# Altium schematic sheet nrf52840_v1.SchDoc
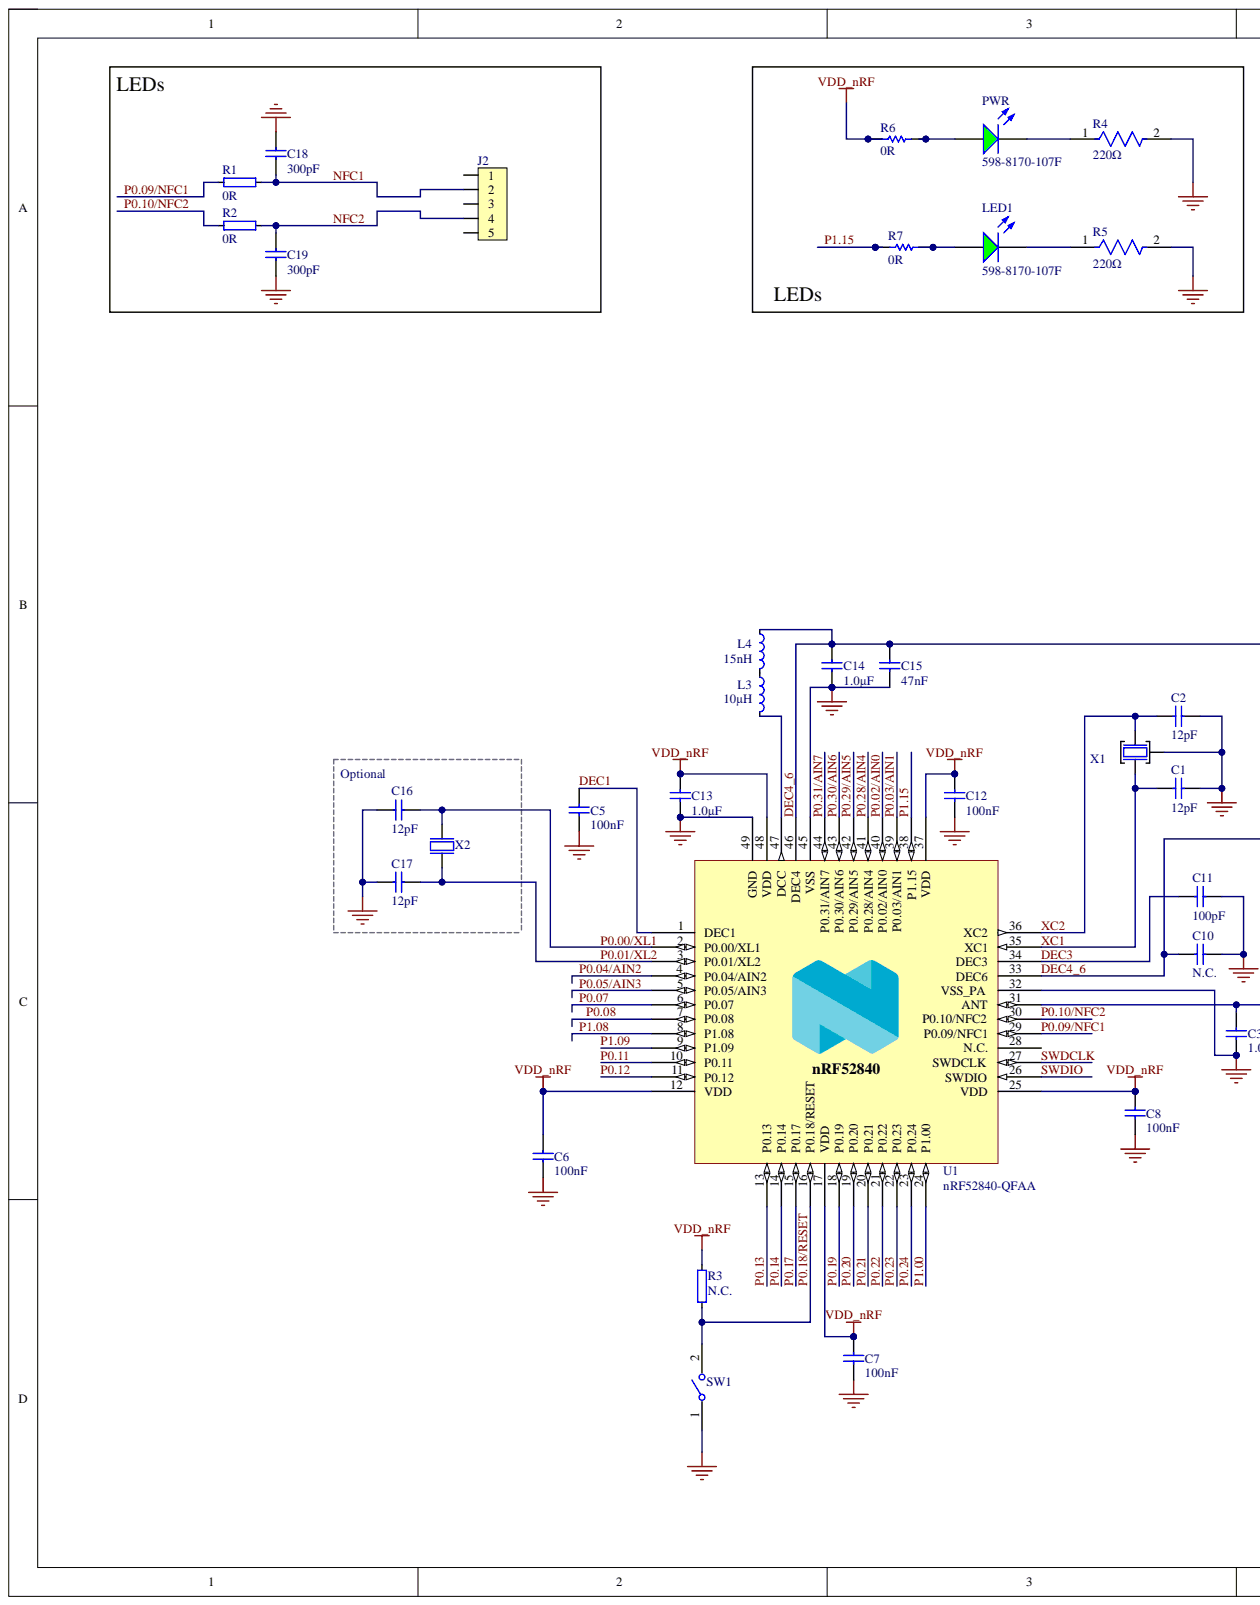
[diagram p0: nrf52840_v1.SchDoc, left]
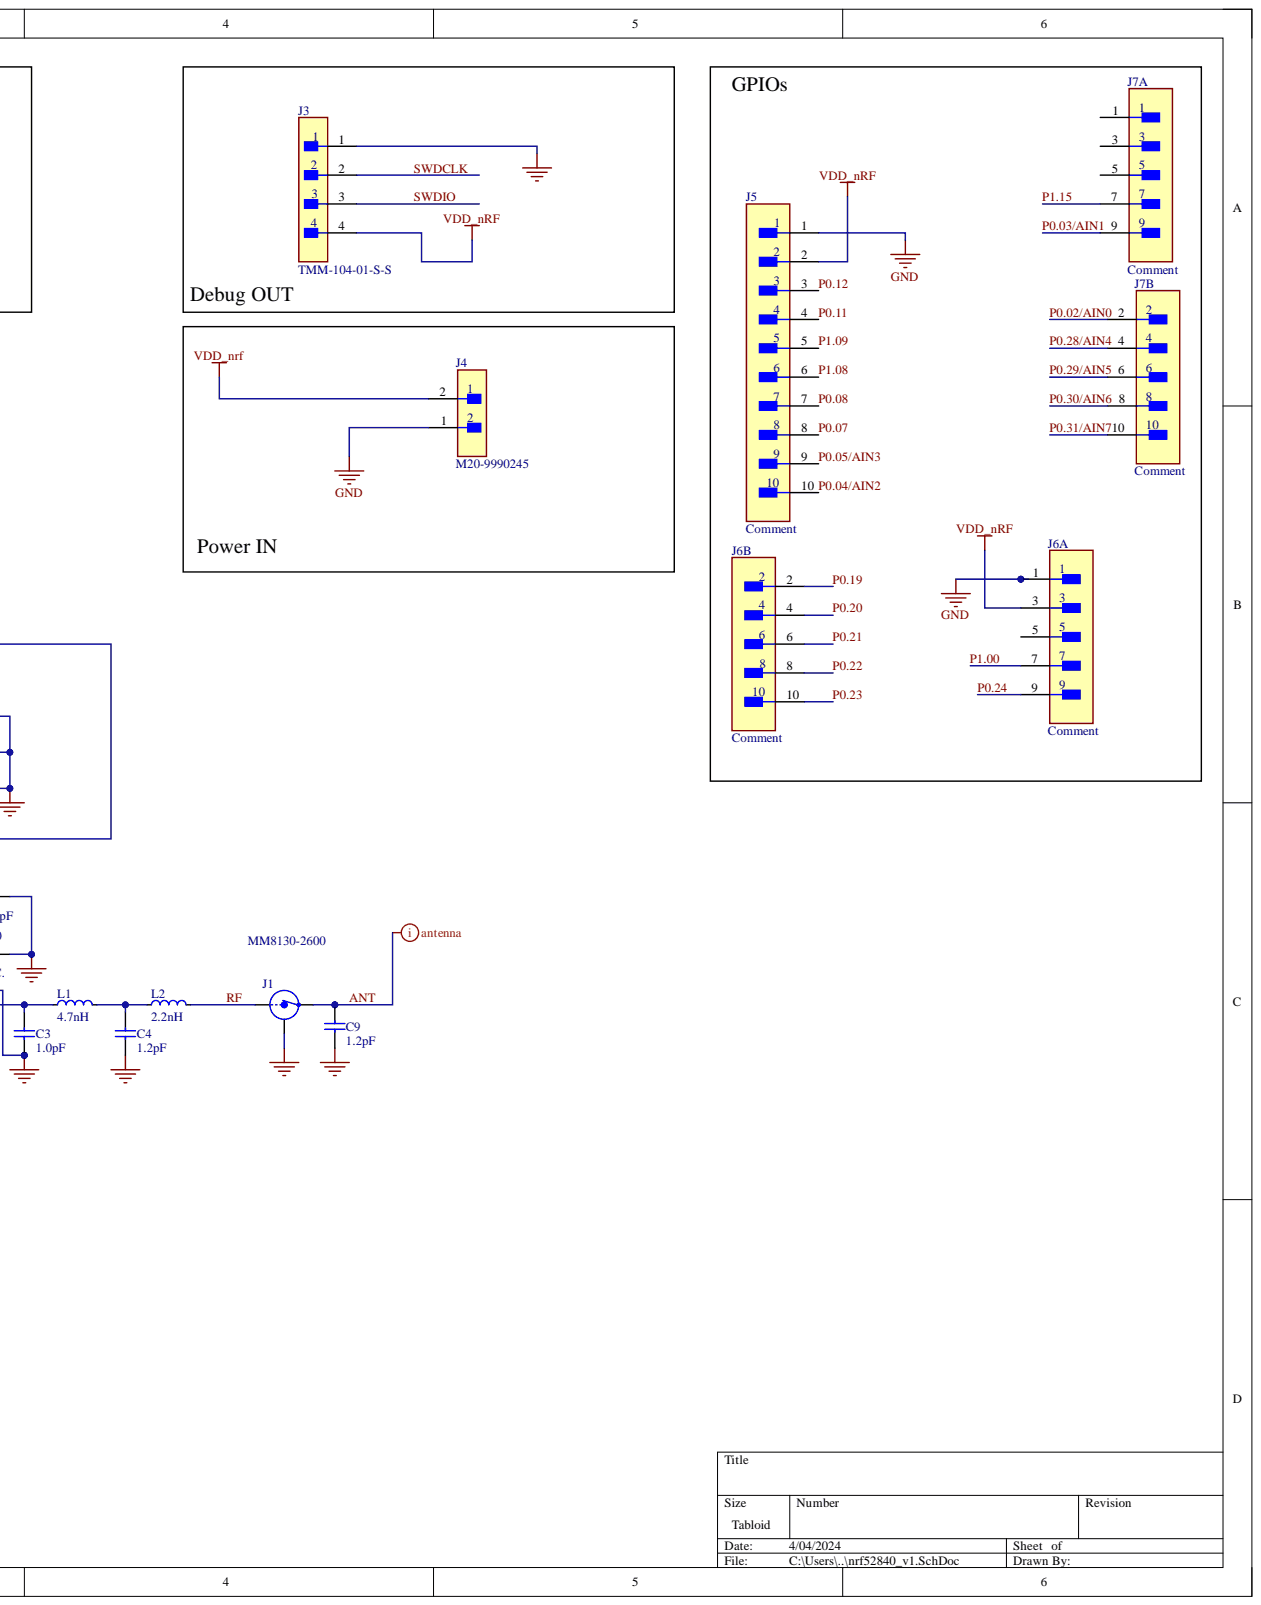
[diagram p1: nrf52840_v1.SchDoc, right]

## Parts
C1  12pF  [CAPC0603X03L_C]
C2  12pF  [CAPC0603X03L_C]
C3  1.0pF  [CAPC0603X03L_C]
C4  1.2pF  [CAPC0603X03L_C]
C5  100nF  [CAPC0603X03L_C]
C6  100nF  [CAPC0603X03L_C]
C7  100nF  [CAPC0603X03L_C]
C8  100nF  [CAPC0603X03L_C]
C9  1.2pF  [CAPC1005X04L]
C10  N.C.  [CAPC0603X03L_C_NC]
C11  100pF  [CAPC0603X03L_C]
C12  100nF  [CAPC0603X03L_C]
C13  1.0µF  [CAPC1005X04L]
C14  1.0µF  [CAPC1005X04L]
C15  47nF  [CAPC0603X03L_C]
C16  12pF  [CAPC1005X04L]
C17  12pF  [CAPC1005X04L]
C18  300pF  [CAPC1005X04L]
C19  300pF  [CAPC1005X04L]
J1  MM8130-2600  [COAXIAL-SWF]
J2  (no value)  [FPC_05P-0.5mm-RA-SMD]
J3  TMM-104-01-S-S  [FP-TMM-104-01-S-S-MFG]
J4  M20-9990245  [FP-M20-9990245-MFG]
J5  Comment  [FP-PEC10SAAN-MFG]
J6  Comment  [FP-10-89-7101-MFG]  (parts A,B)
J7  Comment  [FP-10-89-7101-MFG]  (parts A,B)
L1  4.7nH  [INDC0603X03L_C]
L2  2.2nH  [INDC0603X03L_C]
L3  10µH  [INDC1608X06L]
L4  15nH  [INDC1005X04L]
LED1  598-8170-107F  [DIAL-0805-2_V]
PWR  598-8170-107F  [DIAL-0805-2_V]
R1  0R  [RESC1005X04L]
R2  0R  [RESC1005X04L]
R3  N.C.  [RESC1005X04L]
R4  220Ω  [FP-RT0805-MFG]
R5  220Ω  [FP-RT0805-MFG]
R6  0R  [RESC2013X60X35LL10T20]  (no BOM)
R7  0R  [RESC2013X60X35LL10T20]  (no BOM)
SW1  (no value)  [FP-B3U-1000P-MFG]
U1  nRF52840-QFAA  [QFN40P600X600X90-48N]
X1  (no value)  [XTAL_2016_NT]
X2  (no value)  [XTAL_2012]

## Nets
GND: C1.2 C2.2 C3.1 C4.1 C5.2 C6.2 C7.2 C8.2 C9.2 C10.1 C11.2 C12.2 C13.2 C14.1 C15.2 C16.2 C17.2 C18.2 C19.1 J1.2 J3.1 J4.1 J5.1 J6.1 R4.2 R5.2 SW1.1 U1.32(VSS_PA) U1.45(VSS) U1.49 X1.2
VDD_nrf: C6.1 C7.1 C8.1 C12.1 C13.1 J3.4 J4.2 J5.2 J6.3 R3.2 R6.1 U1.12(VDD) U1.17(VDD) U1.25(VDD) U1.37(VDD) U1.48(VDD)
ANT: C9.1 J1.3
DEC1: C5.1 U1.1(DEC1)
DEC3: C11.1 U1.34(DEC3)
DEC4_6: C10.2 C14.2 C15.1 L4.1 U1.33(DEC6) U1.46(DEC4)
NFC1: C18.1 J2.2 R1.1
NFC2: C19.2 J2.4 R2.1
P0.00/XL1: C16.1 U1.2(P0.00/XL1) X2.1
P0.01/XL2: C17.1 U1.3(P0.01/XL2) X2.2
P0.02/AIN0: J7.2 U1.40(P0.02/AIN0)
P0.03/AIN1: J7.9 U1.39(P0.03/AIN1)
P0.04/AIN2: J5.10 U1.4(P0.04/AIN2)
P0.05/AIN3: J5.9 U1.5(P0.05/AIN3)
P0.07: J5.8 U1.6(P0.07)
P0.08: J5.7 U1.7(P0.08)
P0.09/NFC1: R1.2 U1.29(P0.09/NFC1)
P0.10/NFC2: R2.2 U1.30(P0.10/NFC2)
P0.11: J5.4 U1.10(P0.11)
P0.12: J5.3 U1.11(P0.12)
P0.13: U1.13(P0.13)
P0.14: U1.14(P0.14)
P0.17: U1.15(P0.17)
P0.18/RESET: R3.1 SW1.2 U1.16(P0.18/RESET)
P0.19: J6.2 U1.18(P0.19)
P0.20: J6.4 U1.19(P0.20)
P0.21: J6.6 U1.20(P0.21)
P0.22: J6.8 U1.21(P0.22)
P0.23: J6.10 U1.22(P0.23)
P0.24: J6.9 U1.23(P0.24)
P0.28/AIN4: J7.4 U1.41(P0.28/AIN4)
P0.29/AIN5: J7.6 U1.42(P0.29/AIN5)
P0.30/AIN6: J7.8 U1.43(P0.30/AIN6)
P0.31/AIN7: J7.10 U1.44(P0.31/AIN7)
P1.00: J6.7 U1.24(P1.00)
P1.08: J5.6 U1.8(P1.08)
P1.09: J5.5 U1.9(P1.09)
P1.15: J7.7 R7.1 U1.38(P1.15)
RF: J1.1 L2.2
SWDCLK: J3.2 U1.27(SWDCLK)
SWDIO: J3.3 U1.26(SWDIO)
XC1: C1.1 U1.35(XC1) X1.1
XC2: C2.1 U1.36(XC2) X1.3
NetC3_2: C3.2 L1.1 U1.31(ANT)
NetC4_2: C4.2 L1.2 L2.1
NetL3_1: L3.1 L4.2
NetL3_2: L3.2 U1.47(DCC)
NetLED1_1: LED1.1 R7.2
NetLED1_2: LED1.2 R5.1
NetPWR_1: PWR.1 R6.2
NetPWR_2: PWR.2 R4.1

Not connected: J2 1 3 5; J6 5; J7 1 3 5; U1 28(N.C.)

## Library data of the parts
C1 C2 = C0201-12p: Capacitor, NP0, ±2%; Pad Count: 2; Component Class: Capacitors; Component Kind: Standard; Footprint Doc: 0201; LatestRevisionDate: 2017-11-09; Part Number: C0201-12p; Published: 2014-11-13; Value: 12pF; Library Name: nrf52840_qfaa.IntLib
C3 = C0201-1.0p: Capacitor, NP0, ±10%; Pad Count: 2; Component Class: Capacitors; Component Kind: Standard; Footprint Doc: 0201; LatestRevisionDate: 2018-10-22; Part Number: C0201-1.0p; Published: 2014-11-13; Value: 1.0pF; Library Name: nrf52840_qfaa.IntLib
C4 = C0201-1.2p: Capacitor, NP0, ±10%; Pad Count: 2; Component Class: Capacitors; Component Kind: Standard; Footprint Doc: 0201; LatestRevisionDate: 2020-10-13; Part Number: C0201-1.2p; Published: 2014-11-13; Value: 1.2pF; Library Name: nrf52840_qfaa.IntLib
C5-C8 C12 = C0201-100n: Capacitor, X5R, ±10%; Pad Count: 2; Component Class: Capacitors; Component Kind: Standard; Footprint Doc: 0201; LatestRevisionDate: 2017-11-09; Part Number: C0201-100n; Published: 2014-11-13; Value: 100nF; Library Name: nrf52840_qfaa.IntLib
C9 = C0402-1.2p: Capacitor, NP0, ±0.1pF; Manufacturer: N.A.; Part number IPS: 00000005410; Artikkelnr Noca: 20029157; Note: 50V; Pad Count: 2; Component Class: Capacitors; Component Kind: Standard; ComponentLink1Description: Datasheet; ComponentLink1URL: N.A.; ComponentLink2Description: Supplier link; ComponentLink2URL: N.A.; Footprint Doc: 0402; HelpURL: N.A.; LatestRevisionDate: 2011-05-11; Library Name: pca10056.IntLib; Manufacturer Part Number: N.A.; Part Number: C0402-1.2p; Part number Norautron: N.A.; PartId: 100200119; Pin Count: 2; Published: 2009-05-08; Supplier 1: N.A.; Supplier 2: N.A.; Supplier Part Number 1: N.A.; Supplier Part Number 2: N.A.; Value: 1.2pF; Author: rsk; Publisher: Nordic Semiconductor
C10 = C0201-N.C.: Not mounted; Assembly: Not mounted; Pad Count: 2; Component Class: Capacitors; Component Kind: Standard; Footprint Doc: 0201; LatestRevisionDate: 2014-11-13; Part Number: C0201-N.C.; Published: 2014-11-13; Value: N.C.; Library Name: nrf52840_qfaa.IntLib
C11 = C0201-100p: Capacitor, NP0, ±5%; Pad Count: 2; Component Class: Capacitors; Component Kind: Standard; Footprint Doc: 0201; LatestRevisionDate: 2019-04-16; Part Number: C0201-100p; Published: 2019-04-16; Value: 100pF; Library Name: nrf52840_qfaa.IntLib
C13 C14 = C0402-1µ0: Capacitor, X5R, ±10%; Pad Count: 2; Component Class: Capacitors; Component Kind: Standard; Footprint Doc: 0402; LatestRevisionDate: 2016-08-22; Part Number: C0402-1µ0; Published: 2009-05-08; Value: 1.0µF; Library Name: nrf52840_qfaa.IntLib
C15 = C0201-47n: Capacitor, X5R, ±10%; Pad Count: 2; Component Class: Capacitors; Component Kind: Standard; Footprint Doc: 0201; LatestRevisionDate: 2017-11-09; Part Number: C0201-47n; Published: 2014-11-13; Value: 47nF; Library Name: nrf52840_qfaa.IntLib
C16 C17 = C0402-12p: Capacitor, NP0, ±2%; Manufacturer: N.A.; Part number IPS: 00000002398; Artikkelnr Noca: 20029159; Note: 50V; Pad Count: 2; Component Class: Capacitors; Component Kind: Standard; ComponentLink1Description: Datasheet; ComponentLink1URL: N.A.; ComponentLink2Description: Supplier link; ComponentLink2URL: N.A.; Footprint Doc: 0402; HelpURL: N.A.; LatestRevisionDate: 2011-05-11; Library Name: pca10056.IntLib; Manufacturer Part Number: N.A.; Part Number: C0402-12p; Part number Norautron: N.A.; PartId: 100200137; Pin Count: 2; Published: 2009-05-08; Supplier 1: N.A.; Supplier 2: N.A.; Supplier Part Number 1: N.A.; Supplier Part Number 2: N.A.; Value: 12pF; Author: rsk; Publisher: Nordic Semiconductor
C18 C19 = C0402-300p: Capacitor, NP0, ±5%; Manufacturer: N.A.; Part number IPS: 00000007788; Artikkelnr Noca: 20020004; Note: 50V; Pad Count: 2; Component Class: Capacitors; Component Kind: Standard; ComponentLink1Description: Datasheet; ComponentLink1URL: N.A.; ComponentLink2Description: Supplier link; ComponentLink2URL: N.A.; Footprint Doc: 0402; HelpURL: N.A.; LatestRevisionDate: 2020-10-13; Library Name: pca10056.IntLib; Manufacturer Part Number: N.A.; Part Number: C0402-300p; Part number Norautron: N.A.; PartId: 100200155; Pin Count: 2; Published: 2015-05-29; Supplier 1: Digi-Key; Supplier 2: N.A.; Supplier Part Number 1: 490-3234-1-ND; Supplier Part Number 2: N.A.; Value: 300pF; Author: rsk; Publisher: Nordic Semiconductor
J1 = MM8130-2600: Coaxial Connector with Switch; Manufacturer: Murata; Part number IPS: 00000009955; Artikkelnr Noca: 55029011; Note: N.A.; Pad Count: 3; Component Class: Connectors; Component Kind: Standard; ComponentLink1Description: Datasheet; ComponentLink1URL: N.A.; ComponentLink2Description: Supplier link; ComponentLink2URL: N.A.; Footprint Doc: COAXIAL-SWF; HelpURL: http://www.murata.com/products/catalog/pdf/o33e.pdf; LatestRevisionDate: 2014-04-24; Library Name: pca10056.IntLib; Manufacturer Part Number: MM8130-2600; Part Number: MM8130-2600; Part number Norautron: N.A.; PartId: 100500400; Pin Count: 3; Published: 2014-04-24; Supplier 1: Digi-Key; Supplier 2: Mouser; Supplier Part Number 1: 490-4981-1-ND; Supplier Part Number 2: 81-MM8130-2600RA2; Value: MM8130-2600; Author: rsk; Publisher: Nordic Semiconductor
J2 = FPC_05P-0.5mm-RA-SMD: 0.5mm FPC, 5P,Right Angle SMT,Double Contact,H=1.2mm,White, Tin plated; Manufacturer: W-CON; Manufacturer Part Number: 5141-05RZDNWR01; Part number IPS: 00000007792; Artikkelnr Noca: 55010020; Note: N.A.; Pad Count: 7; Value: FPC 0.5mm RA SMD; Component Class: Connectors; Component Kind: Standard; ComponentLink1Description: Datasheet; ComponentLink1URL: N.A.; ComponentLink2Description: Supplier link; ComponentLink2URL: N.A.; Footprint Doc: FPC_05P-0.5mm-RA-SMD; HelpURL: \\hizz\pro\app9717\Datasheet\connectors\W-CON\5141-XXRZDNXX0X.pdf; LatestRevisionDate: 2016-04-21; Library Name: pca10056.IntLib; Part Number: FPC_05P-0.5mm-RA-SMD; Part number Norautron: N.A.; PartId: 100500528; Pin Count: 7; Published: 2015-06-22; Supplier 1: Cabcon; Supplier 2: Digi-Key; Supplier Part Number 1: 5141-05RZDNWR01; Supplier Part Number 2: WM9836CT-ND; Author: rsk; Publisher: Nordic Semiconductor
J3 = CMP-02766-001674-1: CONN HEADER VERT 4POS 2MM; Connector Type: Male Pin; Gender: Male; Max Current Rating: 3.9A; Max Voltage Rating (AC): 500V; Number of Contacts: 4; Color: Black; Component Kind: Standard; Contact Material: Bronze; Contact Mating Length: 0.126inch; Contact Plating: Gold; Contact Type: Male Pin; Depth: 1.97mm; Housing Color: Black; Housing Material: Polymer; Lead Free: Lead Free; Length: 8mm; Library Name: Altium Content Vault; Max Operating Temperature: 125°C; Min Operating Temperature: -55°C; Mount: Through Hole; Number of Positions: 4; Number of Rows: 1; Orientation: Straight; Package Quantity: 1; Packaging: Bulk; Pitch: 2mm; Radiation Hardening: No; RoHS Compliant: Yes; Series: FleXYZ™ TMM; Termination: Solder; Width: 1.97mm
J4 = CMP-15831-000105-1: CONN HEADER VERT 2POS 2.54MM; Connector Type: Male Pin; Contact Resistance: 25mR; Current: 3A; Gender: Male; Insulation Resistance: 10GR; Mated Stacking Heights: 16.54mm; Mating Cycles: 300; Number of Contacts: 2; Voltage: 50V; Voltage Rating (AC): 750V; Case/Package: SIL; Color: Black; Component Kind: Standard; Contact Finish - Mating: GOLD; Contact Material: Brass; Contact Mating Length: 0.24inch; Contact Plating: Gold; Contact Shape: Square; Contact Type: Male Pin; Current Rating: 3A; Depth: 2.54mm; Fastening Type: Pull; Flammability Rating: UL94 V-0; Height: 0.12inch; Housing Color: Black; Housing Material: Nylon; Insulation Color: Black; Insulation Material: Nylon; Lead Pitch: 2.54mm; Length: 5.08mm; Library Name: Altium Content Vault; Max Current Rating: 3A; Max Operating Temperature: 105°C; Min Operating Temperature: -40°C; Mount: Through Hole; Number of Poles: 2; Number of Positions: 2; Number of Rows: 1; Orientation: Straight; Package Quantity: 1000; Packaging: Bulk; Pitch: 2.54mm; Radiation Hardening: No; Reach SVHC Compliant: Yes; Series: M20; Termination: Solder; Weight: 0.25lb; Width: 0.25inch; Working Voltage: 750V
J5 = CMP-15831-000029-1: CONN HEADER VERT 10POS 2.54MM; Connector Type: Male Pin; Current Rating: 3A; Gender: Male; Number of Contacts: 10; Voltage Rating: 750V; Case/Package: SIL; Color: Black; Component Kind: Standard; Contact Material: Brass; Contact Mating Length: 0.228inch; Contact Plating: Tin; Contact Type: Male Pin; Housing Material: Nylon; Library Name: Altium Content Vault; Mount: Through Hole; Number of Positions: 10; Number of Rows: 1; Package Quantity: 500; Packaging: Bulk; Pitch: 2.54mm; Radiation Hardening: No; Series: M20; Termination: Solder
J6 J7 = CMP-04776-000908-1: CONN HEADER VERT 10POS 2.54MM; Connector Type: Male Pin; Contact Resistance: 15mR; Current Rating: 3A; Gender: Male; Max Current Rating: 1A; Number of Contacts: 10; Row Spacing: 0.1inch; Voltage Rating: 250V; Color: Black; Component Kind: Standard; Contact Gender: Male; Contact Material: Brass; Contact Mating Length: 0.24inch; Contact Plating: Tin; Contact Type: Male Pin; Depth: 5.08mm; Height: 15.97mm; Housing Color: Black; Housing Material: Thermoplastic; Length: 12.7mm; Library Name: Altium Content Vault; Max Operating Temperature: 105°C; Min Operating Temperature: -40°C; Mount: Through Hole; Number of Positions: 10; Number of Rows: 2; Orientation: Straight; Package Quantity: 1; Packaging: Bulk; Pitch: 2.54mm; RoHS Compliant: Yes; Series: C-Grid® 70280; Termination: Solder
L1 = L0201-4n7: High frequency chip inductor ±5%; Pad Count: 2; Component Class: Inductors; Component Kind: Standard; Footprint Doc: 0201; LatestRevisionDate: 2013-04-10; Part Number: L0201-4n7; Published: 2013-04-10; Value: 4.7nH; Library Name: nrf52840_qfaa.IntLib
L2 = L0201-2n2: High frequency chip inductor ±5%; Pad Count: 2; Component Class: Inductors; Component Kind: Standard; Footprint Doc: 0201; LatestRevisionDate: 2013-04-10; Part Number: L0201-2n2; Published: 2013-04-10; Value: 2.2nH; Library Name: nrf52840_qfaa.IntLib
L3 = L0603-10µ-TDK: Chip inductor, IDC,min = 50 mA, ±20%; Pad Count: 2; Component Class: Inductors; Component Kind: Standard; Footprint Doc: 0603; LatestRevisionDate: 2012-09-19; Part Number: L0603-10µ-TDK; Published: 2019-05-06; Value: 10µH; Library Name: nrf52840_qfaa.IntLib
L4 = L0402-15n: High frequency chip inductor ±10%; Pad Count: 2; Component Class: Inductors; Component Kind: Standard; Footprint Doc: 0402; LatestRevisionDate: 2012-09-19; Part Number: L0402-15n; Published: 2010-07-12; Value: 15nH; Library Name: nrf52840_qfaa.IntLib
LED1 = CMP-2000-05655-1: Single Color, 2 V, -30 to 85 degC, 2-Pin SMD (0805), RoHS, Tape and Reel; Manufacturer: Dialight; Chip Material: GaP; DELETED: Compliant; Dominant Wave Length: 570nm; Emitting Color: YellowGreen; Forward Current: 25mA; Forward Voltage: 2.4V; High Brightness: No; Lum Intensity: 20mcd; Main Category: ChipLED; Number of  Elements: 1; Power Dissipation: 60W; Product Depth (mm): 1.25mm; Product Height (mm): 0.7mm; Product Length (mm): 2mm; Reverse Voltage: 5V; Shape Type: Rectangular; Test Current (It): 20mA; Type: Uni-Color; Viewing Angle: 140deg; Component Kind: Standard; Datasheet URL: https://datasheet.ciiva.com/22748/1484480-22748121.pdf; Datasheet Version: 10/2008; Lens Dimensions: 1.4x1.25x0.4mm; Library Name: Altium Content Vault; Luminous Intensity: 20mcd; Manufacturer URL: http://www.dialight.com/; Mounting: SurfaceMount; Mounting Technology: SurfaceMount; Operating Temp Range: -30Cto85C; Operating Temperature Classification: Commercial; Package Description: 2-Pin Surface Mount Device, Body 2 x 1.25 mm; Package Reference: 0805; Package Type: SMD; Packaging: TapeandReel; Pin Count: 2; Rad Hardened: No
PWR = CMP-2000-05655-1: Single Color, 2 V, -30 to 85 degC, 2-Pin SMD (0805), RoHS, Tape and Reel; Manufacturer: Dialight; Chip Material: GaP; DELETED: Compliant; Dominant Wave Length: 570nm; Emitting Color: YellowGreen; Forward Current: 25mA; Forward Voltage: 2.4V; High Brightness: No; Lum Intensity: 20mcd; Main Category: ChipLED; Number of  Elements: 1; Power Dissipation: 60W; Product Depth (mm): 1.25mm; Product Height (mm): 0.7mm; Product Length (mm): 2mm; Reverse Voltage: 5V; Shape Type: Rectangular; Test Current (It): 20mA; Viewing Angle: 140deg; Component Kind: Standard; Datasheet URL: https://datasheet.ciiva.com/22748/1484480-22748121.pdf; Datasheet Version: 10/2008; Lens Dimensions: 1.4x1.25x0.4mm; Library Name: Altium Content Vault; Luminous Intensity: 20mcd; Manufacturer URL: http://www.dialight.com/; Mounting: SurfaceMount; Mounting Technology: SurfaceMount; Operating Temp Range: -30Cto85C; Operating Temperature Classification: Commercial; Package Description: 2-Pin Surface Mount Device, Body 2 x 1.25 mm; Package Reference: 0805; Package Type: SMD; Packaging: TapeandReel; Pin Count: 2; Rad Hardened: No; Type: Uni-Color
R1 R2 = R0402-0R: Resistor, ±5%, 0.063W; Manufacturer: N.A.; Part number IPS: 00000007128; Artikkelnr Noca: 27049000; Note: Chip Res 5%; Pad Count: 2; Component Class: Resistors; Component Kind: Standard; ComponentLink1Description: Datasheet; ComponentLink1URL: N.A.; ComponentLink2Description: Supplier link; ComponentLink2URL: N.A.; Footprint Doc: 0402; HelpURL: N.A.; LatestRevisionDate: 2009-11-23; Library Name: pca10056.IntLib; Manufacturer Part Number: N.A.; Part Number: R0402-0R; Part number Norautron: N.A.; PartId: 100100109; Pin Count: 2; Published: 2009-05-08; Supplier 1: Farnell; Supplier 2: N.A.; Supplier Part Number 1: 146-9661; Supplier Part Number 2: N.A.; Value: 0R; Author: rsk; Publisher: Nordic Semiconductor
R3 = R0402-N.C.: Not mounted; Artikkelnr Noca: N.A.; Assembly: Not mounted; Note: Chip Res; Pad Count: 2; Component Class: Resistors; Component Kind: Standard; ComponentLink1Description: Datasheet; ComponentLink1URL: N.A.; ComponentLink2Description: Supplier link; ComponentLink2URL: N.A.; Footprint Doc: 0402; HelpURL: N.A.; LatestRevisionDate: 2009-05-08; Library Name: pca10056.IntLib; Manufacturer: N.A.; Manufacturer Part Number: N.A.; Part Number: R0402-N.C.; Part number IPS: N.A.; Part number Norautron: N.A.; PartId: 100100281; Pin Count: 2; Published: 2009-05-08; Supplier 1: N.A.; Supplier 2: N.A.; Supplier Part Number 1: N.A.; Supplier Part Number 2: N.A.; Value: N.C.; Author: rsk; Publisher: Nordic Semiconductor
R4 R5 = CMP-2001-01638-2: RT0805 220Ω 0.125W 25 ppm/°C 0.25%; Manufacturer: Yageo; Manufacturer Part Number: RT0805BRD07220RL; Tolerance: 0.0025; Voltage Rating: 150V; Automotive: No; Category: Res; Component Kind: Standard; ComponentLink2Description: Datasheet; ComponentLink2URL: http://www.yageo.com/documents/recent/PYu-RT_1-to-0.01_RoHS_L_9.pdf; Composition: Thin Film; Device Class L1: Passive Components; Device Class L2: Resistors; Device Class L3: Chip SMD Resistors; Digikey Description: RES SMD 220 OHM 0.1% 1/8W 0805; Footprint Url: http://www.yageo.com/exep/pages/download/literatures/PYu-R_Mount_10.pdf; Height: 0.6mm; Ipc Land Pattern Name: RESC200125X50; Lead Free: Yes; Library Name: Altium Content Vault; Mouser Description: Thin Film Resistors - SMD 1/8W 220 ohm .1% 25ppm; Octopart: https://octopart.com/rt0805brd07220rl-yageo-1252408; Package: RT0805; Power Rating: 0.125W; Resistance: 220Ω; RoHS: TRUE; Series: RT; Temperature: -55°C to +155°C; Temperature Coefficient: 25 ppm/°C; Temperature Range High: +155°C; Temperature Range Low: -55°C; Value: 220Ω
R6 R7 = CMP-2001-01414-1: Manufacturer: Yageo; Part Number: RC0805JR-130RL; Library Name: Altium Content Vault
SW1 = CMP-2100-03586-2: SWITCH TACTILE SPST-NO 0.05A 12V; Actuator Length: 0.4mm; Actuator Type: Standard; Contact Current Rating: 50mA; Contact Resistance: 100mR; Electrical Life: 200000Cycles; Illumination Type: Non-Illuminated; Operating Force: 1.5N; Ratings: Dust Proof; Switch Function: Off-Mom; Switch Travel: 0.15mm; Throw Configuration: SPST; Component Kind: Standard; Contact Material: Silver; Current Rating: 50mA; Depth: 2.5mm; Height: 1.2mm; Insulation Resistance: 100MR; Lead Free: Lead Free; Length: 4mm; Library Name: Altium Content Vault; Max Current Rating: 50mA; Max Operating Temperature: 70°C; Max Voltage Rating (DC): 12V; Mechanical Life: 200000Cycles; Min Operating Temperature: -25°C; Mount: Surface Mount; Orientation: Straight; Package Quantity: 1; Packaging: Tape and Reel; Termination: SMD/SMT; Voltage Rating (DC): 12V; Weight: 0.000776oz; Width: 3mm
U1 = nRF52840-QFAA: Multi-protocol Bluetooth Low Energy, IEEE 802.15.4, ANT and 2.4GHz proprietary system-on-chip; Pad Count: 48; Component Class: nRF_Devices; Component Kind: Standard; Footprint Doc: QFN-48; LatestRevisionDate: 2016-11-22; Library Name: nrf52840_qfaa.IntLib; Part Number: nRF52840-QFAA; Published: 2016-03-31; Value: nRF52840-QFAA
X1 = XTAL_SMD2016_32MHz_8pF_General_NT: XTAL SMD 2016, 32MHz, Cl=8pF, Total Tol: ±30ppm; Pad Count: 4; Component Class: Oscillators; Component Kind: Standard; Footprint Doc: XTAL_2016; LatestRevisionDate: 2021-04-08; Part Number: XTAL_SMD2016_32MHz_8pF_General_NT; Published: 2021-04-08; Value: 32MHz; Library Name: nrf52840_qfaa.IntLib
X2 = XTAL_SMD2012_32.768kHz_9pF_General: XTAL SMD 2012, 32.768kHz, Cl=9pF, Total Tol: ±50ppm; Pad Count: 2; Component Class: Oscillators; Component Kind: Standard; Footprint Doc: XTAL_2012; LatestRevisionDate: 2017-01-11; Part Number: XTAL_SMD2012_32.768kHz_9pF_General; Published: 2016-08-19; Value: 32.768kHz; Library Name: nrf52840_qfaa.IntLib
